# Altium schematic sheet sensors.SchDoc
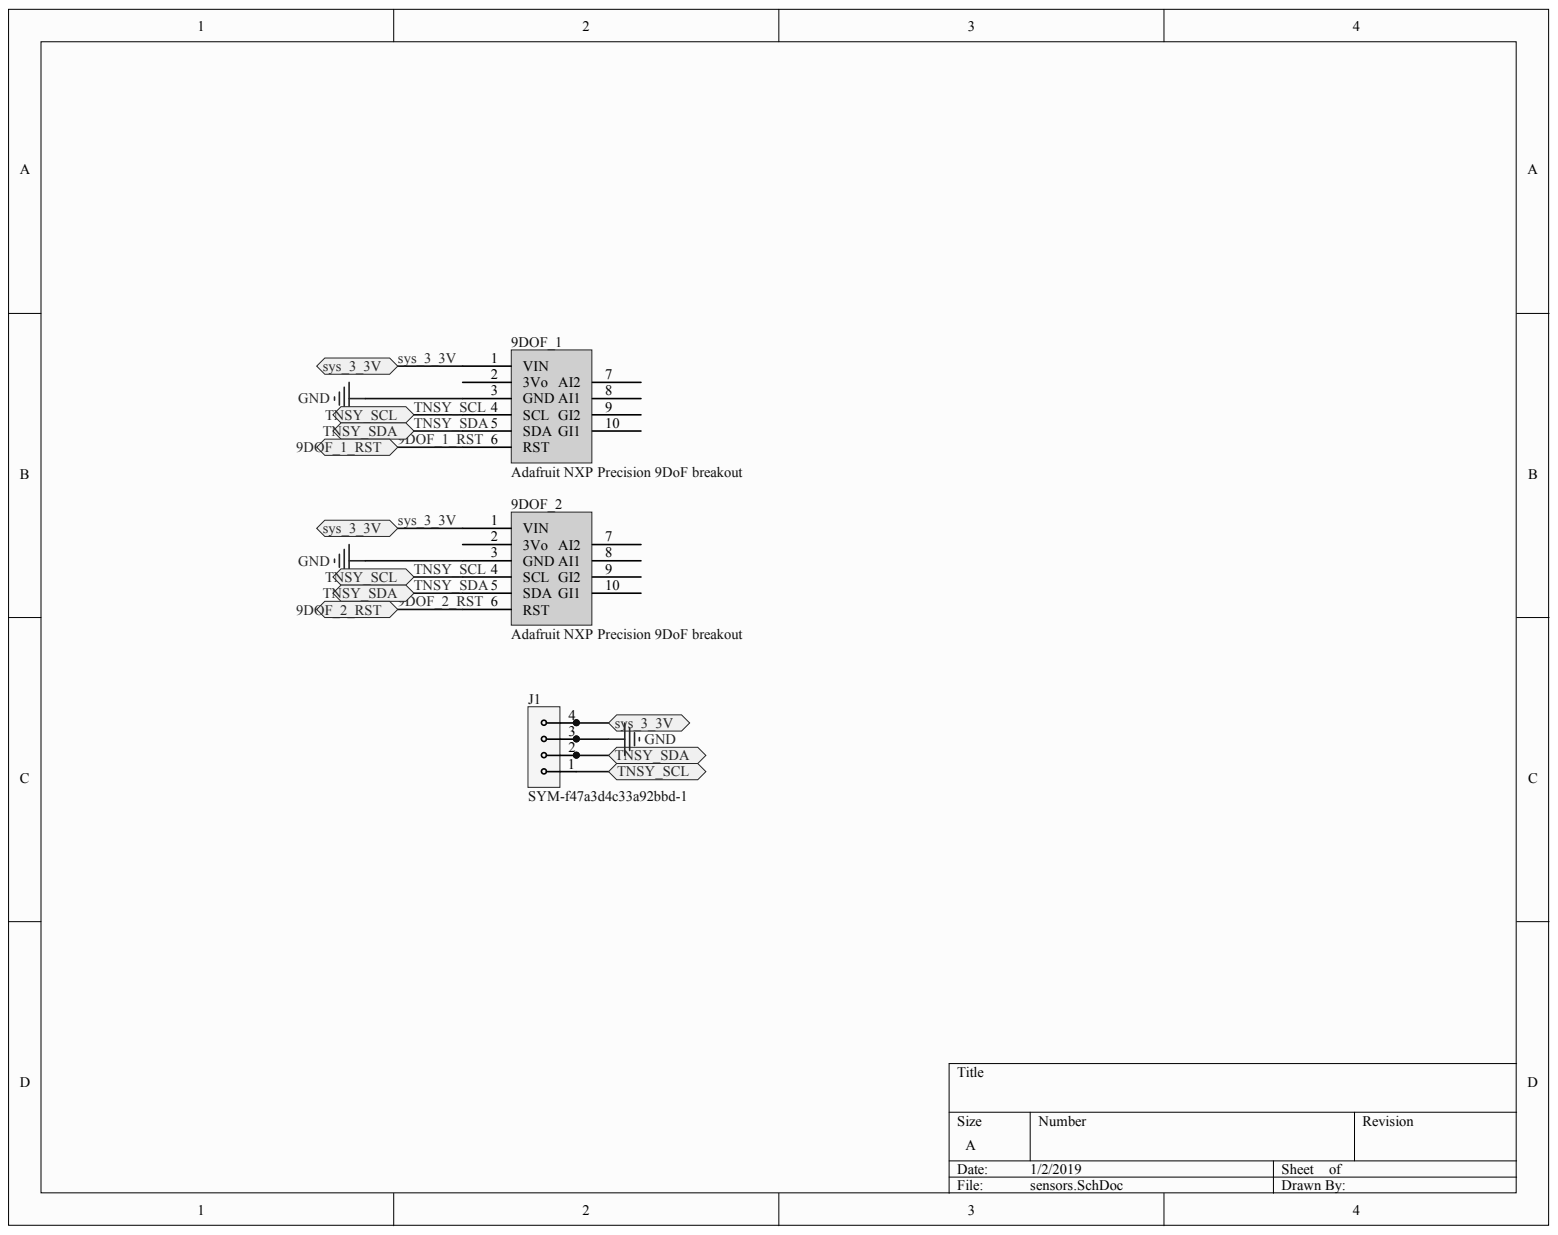
[diagram p0: sensors.SchDoc]

## Parts
9DOF_1  Adafruit NXP Precision 9DoF breakout  [PCB-qycbgvnmg1jf60fg22jb-1]
9DOF_2  Adafruit NXP Precision 9DoF breakout  [PCB-qycbgvnmg1jf60fg22jb-1]
J1  SYM-f47a3d4c33a92bbd-1  [PCBComponent_1]

## Ports
9DOF_1_RST, 9DOF_2_RST, SYS_3_3V, TNSY_SCL, TNSY_SDA

## Nets
GND: 9DOF_1.3(GND) 9DOF_2.3(GND) J1.3
9DOF_1_RST: 9DOF_1.6(RST) ; port 9DOF_1_RST
9DOF_2_RST: 9DOF_2.6(RST) ; port 9DOF_2_RST
SYS_3_3V: 9DOF_1.1(VIN) 9DOF_2.1(VIN) J1.4 ; port SYS_3_3V
TNSY_SCL: 9DOF_1.4(SCL) 9DOF_2.4(SCL) J1.1 ; port TNSY_SCL
TNSY_SDA: 9DOF_1.5(SDA) 9DOF_2.5(SDA) J1.2 ; port TNSY_SDA

Not connected: 9DOF_1 2(3Vo) 7(AI2) 8(AI1) 9(GI2) 10(GI1); 9DOF_2 2(3Vo) 7(AI2) 8(AI1) 9(GI2) 10(GI1)

## Library data of the parts
9DOF_1 9DOF_2 = CMP-4rmpjjg8h9uj8rll3hj9-1: Adafruit board breakout of the The FXOS8700 3-Axis accelerometer and magnetometer, and the FXAS21002 3-axis gyroscope.; Serial Protocol: I2C; Vin: 3 - 5V; Component Kind: Standard; CustomComponentID: 4rmpjjg8h9uj8rll3hj9; Library Name: FavoritesLibrary
J1 = CMP-f47a3d4c33a92bbd-2: Socket, wire-board, male, XH, 2.5mm, PIN: 4, THT, 250V 3A, -25ºC to +85ºC; Manufacturer Part Number: B4B-XH-A; Component Kind: Standard; Contact Material: Phosphor Bronze; Library Name: CommunityVault; Octopart URL: https://octopart.com/b4b-xh-a-jst-3990197; Orientation: Straight; RoHS: Non-Compliant
